# Altium schematic sheet Heat_Compress_V1.2.0.SchDoc
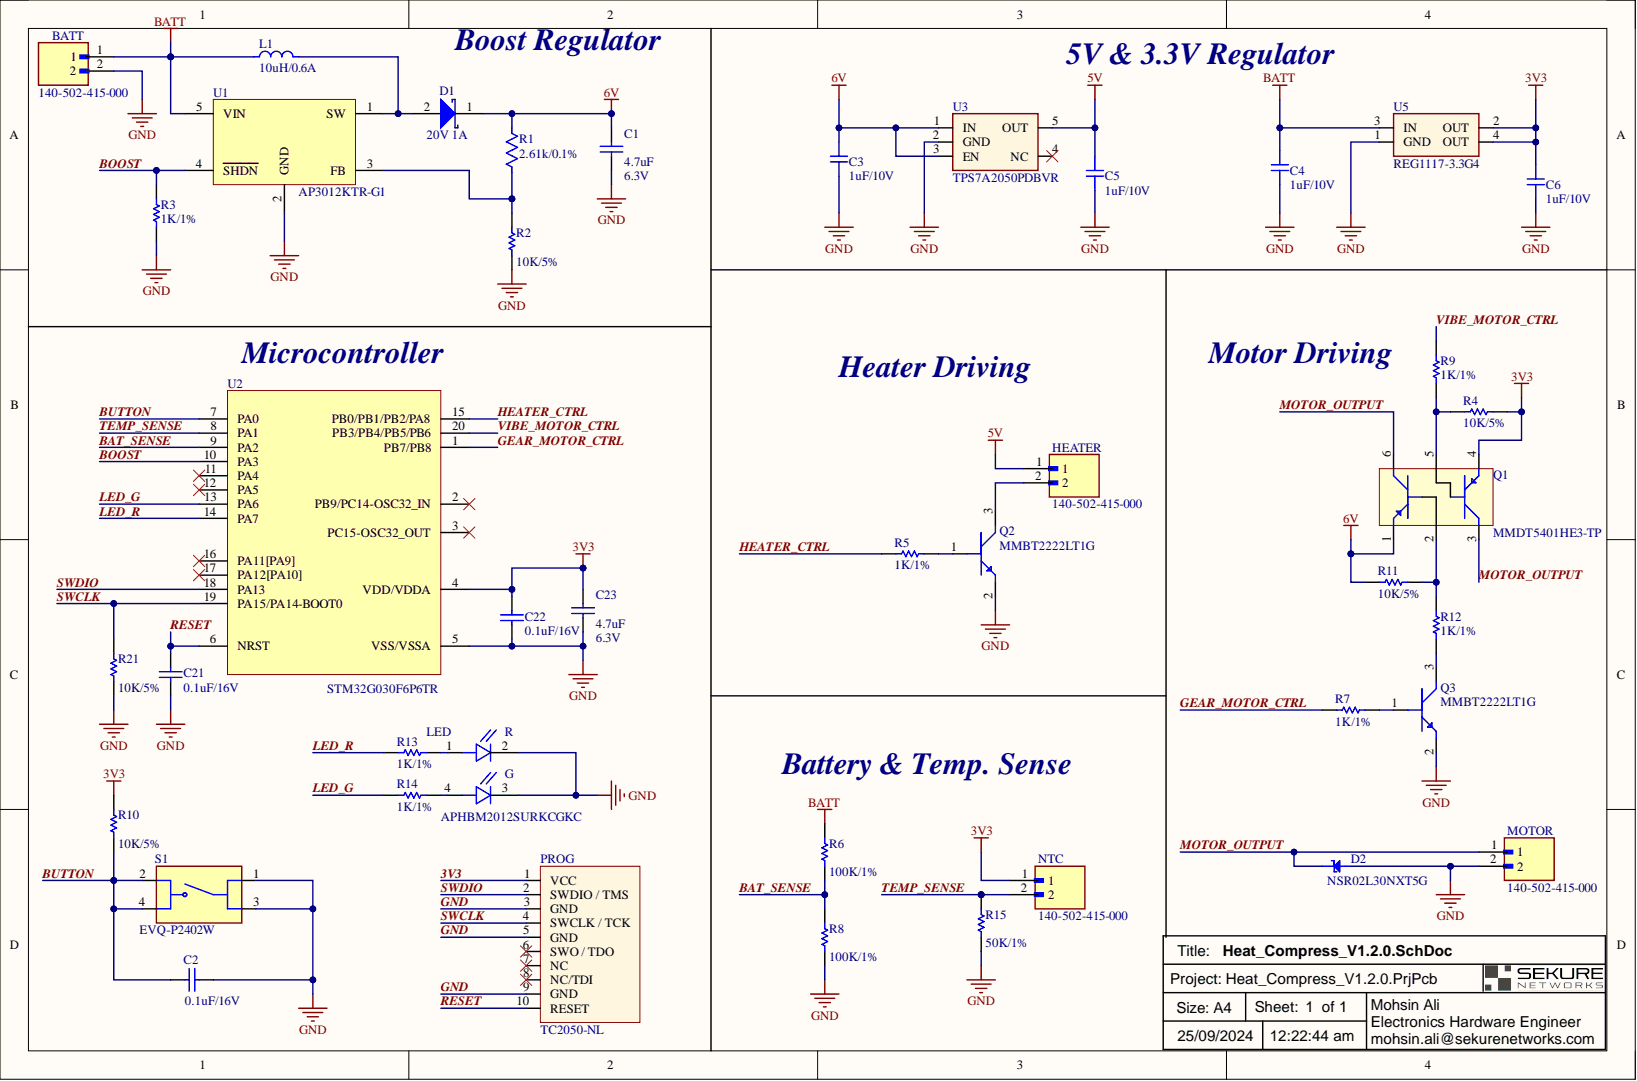
[diagram p0: Heat_Compress_V1.2.0.SchDoc]

## Parts
BATT  value 140-502-415-000  [140502415000]  (hidden comment: Connector)
C1  value 4.7uF  Capacitance: 4.7uF  Voltage Rating: 6.3V  [C0402]  (hidden comment: 4.7uF/6.3V)
C2  value 0.1uF/16V  [C0402]  (hidden comment: Ceramic Capacitor)
C3  value 1uF/10V  [CAP 0402]  (hidden comment: Ceramic Capacitor)
C4  value 1uF/10V  [CAP 0402]  (hidden comment: Ceramic Capacitor)
C5  value 1uF/10V  [CAP 0402]  (hidden comment: Ceramic Capacitor)
C6  value 1uF/10V  [CAP 0402]  (hidden comment: Ceramic Capacitor)
C21  value 0.1uF/16V  [C0402]  (hidden comment: Ceramic Capacitor)
C22  value 0.1uF/16V  [C0402]  (hidden comment: Ceramic Capacitor)
C23  value 4.7uF  Capacitance: 4.7uF  Voltage Rating: 6.3V  [C0402]  (hidden comment: 4.7uF/6.3V)
D1  value 20V 1A  Average Rectified Current: 1A  Max Repetitive Reverse Voltage (Vrrm): 20V  [SOD-123FL]  (hidden comment: Diode)
D2  value NSR02L30NXT5G  [2-DSN (0.60x0.30)]  (hidden comment: Schottky Diode)
HEATER  value 140-502-415-000  [140502415000]  (hidden comment: Connector)
L1  value 10uH/0.6A  [0.150" L x 0.150" W (3.80mm x 3.80mm)]  (hidden comment: Inductor)
LED  LED  value APHBM2012SURKCGKC  [LED_APHBM2012SURKCGKC]
MOTOR  value 140-502-415-000  [140502415000]  (hidden comment: Connector)
NTC  value 140-502-415-000  [140502415000]  (hidden comment: Connector)
PROG  value TC2050-NL  [TC2050]  (hidden comment: Programming Connector)
Q1  value MMDT5401HE3-TP  [SOT-363]  (hidden comment: Transistor)
Q2  value MMBT2222LT1G  [SOT23]  (hidden comment: Transistor)
Q3  value MMBT2222LT1G  [SOT23]  (hidden comment: Transistor)
R1  value 2.61k/0.1%  [RES 0402]  (hidden comment: Resistor)
R2  value 10K/5%  [R0402]  (hidden comment: Resistor)
R3  value 1K/1%  [R0402]  (hidden comment: Resistor)
R4  value 10K/5%  [R0402]  (hidden comment: Resistor)
R5  value 1K/1%  [R0402]  (hidden comment: Resistor)
R6  value 100K/1%  [R0402]  (hidden comment: Resistor)
R7  value 1K/1%  [R0402]  (hidden comment: Resistor)
R8  value 100K/1%  [R0402]  (hidden comment: Resistor)
R9  value 1K/1%  [R0402]  (hidden comment: Resistor)
R10  value 10K/5%  [R0402]  (hidden comment: Resistor)
R11  value 10K/5%  [R0402]  (hidden comment: Resistor)
R12  value 1K/1%  [R0402]  (hidden comment: Resistor)
R13  value 1K/1%  [R0402]  (hidden comment: Resistor)
R14  value 1K/1%  [R0402]  (hidden comment: Resistor)
R15  value 50K/1%  [R0402]  (hidden comment: Resistor)
R21  value 10K/5%  [R0402]  (hidden comment: Resistor)
S1  value EVQ-P2402W  [SW_EVQ-P2402W]  (hidden comment: Switch)
U1  AP3012KTR-G1  [SOT23-5]
U2  STM32G030F6P6TR  [TSOP65P640X120-20N]
U3  Regulator  value TPS7A2050PDBVR  [SOT23-5]
U5  Regulator  value REG1117-3.3G4  [SOT-223]

## Nets
5V: C5.2 HEATER.1 U3.5(OUT)
6V: C1.1 C3.2 D1.1 Q1.1 R1.1 R11.1 U3.1(IN) U3.3(EN)
BATT: BATT.1 C4.2 L1.1 R6.1 U1.5(VIN) U5.3(IN)
3V3: C6.2 C22.2 C23.1 NTC.1 PROG.1(VCC) Q1.4 R4.1 R10.2 U2.4(VDD/VDDA) U5.2(OUT) U5.4(OUT)
BAT_SENSE: R6.2 R8.1 U2.9(PA2)
BOOST: R3.1 U1.4(SHDN) U2.10(PA3)
BUTTON: C2.2 R10.1 S1.2 S1.4 U2.7(PA0)
GEAR_MOTOR_CTRL: R7.2 U2.1(PB7/PB8)
GND: BATT.2 C1.2 C2.1 C3.1 C4.1 C5.1 C6.1 C21.1 C22.1 C23.2 D2.2 LED.2 LED.3 MOTOR.2 PROG.3(GND) PROG.5(GND) PROG.9(GND) Q2.2 Q3.2 R2.1 R3.2 R8.2 R15.2 R21.1 S1.1 S1.3 U1.2(GND) U2.5(VSS/VSSA) U3.2(GND) U5.1(GND)
HEATER_CTRL: R5.2 U2.15(PB0/PB1/PB2/PA8)
LED_G: R14.2 U2.13(PA6)
LED_R: R13.2 U2.14(PA7)
MOTOR_OUTPUT: D2.1 MOTOR.1 Q1.3 Q1.6
RESET: C21.2 PROG.10(RESET) U2.6(NRST)
SWCLK: PROG.4(SWCLK_/_TCK) R21.2 U2.19(PA15/PA14-BOOT0)
SWDIO: PROG.2(SWDIO_/_TMS) U2.18(PA13)
TEMP_SENSE: NTC.2 R15.1 U2.8(PA1)
VIBE_MOTOR_CTRL: R9.1 U2.20(PB3/PB4/PB5/PB6)
NetD1_2: D1.2 L1.2 U1.1(SW)
NetHEATER_2: HEATER.2 Q2.3
NetLED_1: LED.1 R13.1
NetLED_4: LED.4 R14.1
NetQ1_2: Q1.2 R11.2 R12.1
NetQ1_5: Q1.5 R4.2 R9.2
NetQ2_1: Q2.1 R5.1
NetQ3_1: Q3.1 R7.1
NetQ3_3: Q3.3 R12.2
NetR1_2: R1.2 R2.2 U1.3(FB)

Not connected: PROG 6(SWO_/_TDO) 7(NC) 8(NC/TDI); U2 2(PB9/PC14-OSC32_IN) 3(PC15-OSC32_OUT) 11(PA4) 12(PA5) 16(PA11[PA9]) 17(PA12[PA10]); U3 4(NC)

## Library data of the parts
BATT HEATER MOTOR NTC = 140-502-415-000: EDAC - 140-502-415-000 - PIN HEADER CONN, BRASS, 2POS, 1ROW, 2MM   Source:  Datasheet; GateName_1: G$1; Component Kind: Standard; DeviceSetName: 140-502-415-000; DigiKey: 151-140-502-415-000-ND; Height: 5.25mm; Library Name: MainSchlib.SchLib; LibraryName: 140-502-415-000; Manufacturer: EDAC; Mouser_Part_Number: 587-140-502-415-000; Mouser_Price-Stock: https://www.mouser.co.uk/ProductDetail/EDAC/140-502-415-000?qs=zW32dvEIR3v5NSNdqekIBw%3D%3D; P/N: 140-502-415-000; Package: 140502415000; SymbolName_1: 140-502-415-000
C1 C23 = 885012206052: CAP CER 4.7UF 6.3V X5R 0402; Manufacturer: KEMET; P/N: C0402C475M9PAC7867; Tolerance: 10%; Case Code (Imperial): 0603; Case Code (Metric): 1608; Case/Package: 0402; Component Kind: Standard; ComponentLink1Description: https://datasheet.ciiva.com/176/mlccorderingcode-176788.pdf; ComponentLink1URL: https://datasheet.ciiva.com/176/mlccorderingcode-176788.pdf; ComponentLink2Description: https://datasheet.ciiva.com/176/mlccorderingcode-176789.pdf; ComponentLink2URL: https://datasheet.ciiva.com/176/mlccorderingcode-176789.pdf; ComponentLink3Description: https://datasheet.ciiva.com/176/mlccorderingcode-176790.pdf; ComponentLink3URL: https://datasheet.ciiva.com/176/mlccorderingcode-176790.pdf; ComponentLink4Description: https://datasheet.ciiva.com/5425/upy-gphc-x7r-6-3v-to-50v-8-12304-5425495.pdf; ComponentLink4URL: https://datasheet.ciiva.com/5425/upy-gphc-x7r-6-3v-to-50v-8-12304-5425495.pdf; ComponentLink5Description: https://datasheet.ciiva.com/16625/upy-gphc-x7r-6-3v-to-50v-8-16625527.pdf; ComponentLink5URL: https://datasheet.ciiva.com/16625/upy-gphc-x7r-6-3v-to-50v-8-16625527.pdf; ComponentLink6Description: https://datasheet.ciiva.com/8033/1734573-8033800.pdf; ComponentLink6URL: https://datasheet.ciiva.com/8033/1734573-8033800.pdf; ComponentLink7Description: https://datasheet.ciiva.com/8033/1734573-8033801.pdf; ComponentLink7URL: https://datasheet.ciiva.com/8033/1734573-8033801.pdf; ComponentLink8Description: https://datasheet.ciiva.com/28450/1489800-28450557.pdf; ComponentLink8URL: https://datasheet.ciiva.com/28450/1489800-28450557.pdf; ComponentLink9Description: https://datasheet.ciiva.com/7978/1489800-7978143.pdf; ComponentLink9URL: https://datasheet.ciiva.com/7978/1489800-7978143.pdf; ComponentLink10Description: https://datasheet.ciiva.com/25788/cl10b105kp8nnnc-25788304.pdf; ComponentLink10URL: https://datasheet.ciiva.com/25788/cl10b105kp8nnnc-25788304.pdf; ComponentLink11Description: https://datasheet.ciiva.com/16396/cl10b105kp8nnnc-16396970.pdf; ComponentLink11URL: https://datasheet.ciiva.com/16396/cl10b105kp8nnnc-16396970.pdf; ComponentLink12Description: https://datasheet.ciiva.com/26838/getdatasheetpartid-358410-26838426.pdf; ComponentLink12URL: https://datasheet.ciiva.com/26838/getdatasheetpartid-358410-26838426.pdf; ComponentLink13Description: http://www.samsungsem.com/kr/front/downloadcms.do?path=/kr/support/product-search/mlcc/__icsFiles/afieldfile/2014/11/05&fileName=C_CL10A475KQ8NNWC.pdf; ComponentLink13URL: http://www.samsungsem.com/kr/front/downloadcms.do?path=/kr/support/product-search/mlcc/__icsFiles/afieldfile/2014/11/05&fileName=C_CL10A475KQ8NNWC.pdf; DigiKey: 399-13068-1-ND; Length: 0.063inch; Library Name: Capacitor_Ceramic.IntLib; Max Operating Temperature: 85°C; Min Operating Temperature: -55°C; Mount: Surface Mount; Package Quantity: 1; Packaging: Tape and Reel; Pricing 1: 1=0.1, 10=0.075, 100=0.0333, 500=0.02376, 1000=0.01867 (USD); Signal Integrity: Cap; Simulation: Cap; Stock 1: 40000; Supplier 1: Digi-Key; Thickness: 0.035inch; Width: 0.031inch
C2 C21 C22 = Cap: CAP CER 0.1UF 16V X7R 0402; Manufacturer: Murata Electronics; P/N: GRM155R71C104KA88J; Category: Ceramic Capacitors; Component Kind: Standard; ComponentLink1Description: https://datasheet.ciiva.com/30062/tmk212bbj106kg-t-30062083.pdf?src-supplier=Onlinecomponents.com; ComponentLink1URL: https://datasheet.ciiva.com/30062/tmk212bbj106kg-t-30062083.pdf?src-supplier=Onlinecomponents.com; ComponentLink2Description: https://datasheet.ciiva.com/139/mlcc-all-e-139570.pdf?src-supplier=Digi-Key; ComponentLink2URL: https://datasheet.ciiva.com/139/mlcc-all-e-139570.pdf?src-supplier=Digi-Key; ComponentLink3Description: https://datasheet.ciiva.com/139/mlcc-all-e-139571.pdf?src-supplier=Digi-Key; ComponentLink3URL: https://datasheet.ciiva.com/139/mlcc-all-e-139571.pdf?src-supplier=Digi-Key; ComponentLink4Description: https://datasheet.ciiva.com/139/mlcc-all-e-139572.pdf?src-supplier=Digi-Key; ComponentLink4URL: https://datasheet.ciiva.com/139/mlcc-all-e-139572.pdf?src-supplier=Digi-Key; ComponentLink5Description: https://datasheet.ciiva.com/7975/1481447-7975820.pdf?src-supplier=Element14; ComponentLink5URL: https://datasheet.ciiva.com/7975/1481447-7975820.pdf?src-supplier=Element14; ComponentLink6Description: https://datasheet.ciiva.com/144/mlccorderingcode-144037.pdf?src-supplier=Digi-Key; ComponentLink6URL: https://datasheet.ciiva.com/144/mlccorderingcode-144037.pdf?src-supplier=Digi-Key; ComponentLink7Description: https://datasheet.ciiva.com/8033/1489800-8033669.pdf?src-supplier=Element14; ComponentLink7URL: https://datasheet.ciiva.com/8033/1489800-8033669.pdf?src-supplier=Element14; ComponentLink8Description: https://datasheet.ciiva.com/15189/getdatasheetpartid-321421-15189125.pdf?src-supplier=Verical; ComponentLink8URL: https://datasheet.ciiva.com/15189/getdatasheetpartid-321421-15189125.pdf?src-supplier=Verical; ComponentLink9Description: https://datasheet.ciiva.com/144/mlccorderingcode-144038.pdf?src-supplier=Digi-Key; ComponentLink9URL: https://datasheet.ciiva.com/144/mlccorderingcode-144038.pdf?src-supplier=Digi-Key; ComponentLink10Description: https://datasheet.ciiva.com/28450/1489800-28450342.pdf?src-supplier=Element14; ComponentLink10URL: https://datasheet.ciiva.com/28450/1489800-28450342.pdf?src-supplier=Element14; ComponentLink11Description: https://datasheet.ciiva.com/144/mlccorderingcode-144039.pdf?src-supplier=Digi-Key; ComponentLink11URL: https://datasheet.ciiva.com/144/mlccorderingcode-144039.pdf?src-supplier=Digi-Key; ComponentLink12Description: https://datasheet.ciiva.com/8033/1489800-8033671.pdf?src-supplier=Element14; ComponentLink12URL: https://datasheet.ciiva.com/8033/1489800-8033671.pdf?src-supplier=Element14; ComponentLink13Description: http://www.farnell.com/datasheets/1872206.pdf?src-supplier=Farnell; ComponentLink13URL: http://www.farnell.com/datasheets/1872206.pdf?src-supplier=Farnell; ComponentLink14Description: http://uk.rs-online.com/webdocs/144c/0900766b8144c113.pdf?src-supplier=RSComponents; ComponentLink14URL: http://uk.rs-online.com/webdocs/144c/0900766b8144c113.pdf?src-supplier=RSComponents; ComponentLink15Description: http://www.farnell.com/datasheets/2048008.pdf?src-supplier=Farnell; ComponentLink15URL: http://www.farnell.com/datasheets/2048008.pdf?src-supplier=Farnell; ComponentLink16Description: http://www.mouser.com/ds/2/281/c02e-2905.pdf?src-supplier=Mouser; ComponentLink16URL: http://www.mouser.com/ds/2/281/c02e-2905.pdf?src-supplier=Mouser; ComponentLink17Description: http://components.arrow.com/part/dataview/0323_06361249?src-supplier=Arrow+Europe; ComponentLink17URL: http://components.arrow.com/part/dataview/0323_06361249?src-supplier=Arrow+Europe; ComponentLink18Description: https://search.murata.co.jp/Ceramy/image/img/A01X/G101/ENG/GRM155R71E104KE14-01.pdf?src-supplier=Digi-Key; ComponentLink18URL: https://search.murata.co.jp/Ceramy/image/img/A01X/G101/ENG/GRM155R71E104KE14-01.pdf?src-supplier=Digi-Key; ComponentLink19Description: http://www.farnell.com/datasheets/2048008.pdf?src-supplier=Newark; ComponentLink19URL: http://www.farnell.com/datasheets/2048008.pdf?src-supplier=Newark; ComponentLink20Description: http://psearch.en.murata.com/capacitor/product/GRM155R71E104KE14%23.pdf?src-supplier=Avnet; ComponentLink20URL: http://psearch.en.murata.com/capacitor/product/GRM155R71E104KE14%23.pdf?src-supplier=Avnet; ComponentLink21Description: http://www.farnell.com/datasheets/2048008.pdf?src-supplier=Element14; ComponentLink21URL: http://www.farnell.com/datasheets/2048008.pdf?src-supplier=Element14; DigiKey: 490-6328-1-ND; Library Name: Miscellaneous Devices.IntLib; Pricing 1: 1=0.10000, 10=0.06400, 50=0.03500, 100=0.02860, 500=0.02040, 1000=0.01603, 2500=0.01458, 5000=0.01341 (USD); RoHS: Yes; RoHS Compliant: Yes; Signal Integrity: Cap; Simulation: CAP; Stock 1: 586694; Supplier 1: Digi-Key; Voltage Rating: 16V
C3-C6 = CL05A105MP5NNNC: CAP CER 1UF 10V X5R 0402; Manufacturer: Samsung; Tolerance: 20%; Capacitance: 1uF; Case Code (Imperial): 0402; Case Code (Metric): 1005; Case/Package: 0402; Category: Ceramic Capacitors; Component Kind: Standard; ComponentLink1Description: https://datasheet.ciiva.com/25802/cl05a105mp5nnnc-25802049.pdf?src-supplier=Digi-Key; ComponentLink1URL: https://datasheet.ciiva.com/25802/cl05a105mp5nnnc-25802049.pdf?src-supplier=Digi-Key; Construction: Flat; Depth: 0.5mm; Dielectric: X5R; DigiKey: 1276-1448-1-ND; Height: 0.5mm; Lead Free: Lead Free; Length: 1mm; Library Name: Ceramic Capacitors.IntLib; Max Operating Temperature: 85°C; Min Operating Temperature: -55°C; Mount: Surface Mount; P/N: CL05A105MP5NNNC; Package Quantity: 1; Packaging: Tape and Reel; Pricing 1: 1=0.10000 (USD); Radiation Hardening: No; RoHS: Yes; RoHS Compliant: Yes; Series: CL; Stock 1: 2439; Supplier 1: Digi-Key; Thickness: 0.022inch; Voltage Rating: 10V; Voltage Rating (DC): 10V; Width: 0.02inch
D1 = D Schottky: DIODE SCHOTTKY 20V 1A SOD123FL; Manufacturer: ON Semiconductor; Element Configuration: Single; Forward Voltage: 340mV; Max Forward Surge Current (Ifsm): 45A; Max Reverse Leakage Current: 600uA; P/N: MBR120VLSFT3G; Polarity: Standard; Component Kind: Standard; ComponentLink1Description: http://www.onsemi.com/pub_link/Collateral/MBR0520LT1-D.PDF; ComponentLink1URL: http://www.onsemi.com/pub_link/Collateral/MBR0520LT1-D.PDF; ComponentLink2Description: https://datasheet.ciiva.com/4715/mbr120vlsft1-d-107081-4715104.pdf; ComponentLink2URL: https://datasheet.ciiva.com/4715/mbr120vlsft1-d-107081-4715104.pdf; ComponentLink3Description: https://datasheet.ciiva.com/6380/mbr120vlsft1-d-6380907.pdf; ComponentLink3URL: https://datasheet.ciiva.com/6380/mbr120vlsft1-d-6380907.pdf; ComponentLink4Description: https://datasheet.ciiva.com/8294/mbr120vlsft1-d-8294603.pdf; ComponentLink4URL: https://datasheet.ciiva.com/8294/mbr120vlsft1-d-8294603.pdf; ComponentLink5Description: https://datasheet.ciiva.com/6380/mbr120vlsft1-d-6380910.pdf; ComponentLink5URL: https://datasheet.ciiva.com/6380/mbr120vlsft1-d-6380910.pdf; Current Rating: 1A; DigiKey: MBR120VLSFT3GOSCT-ND; Forward Current: 1A; Halogen Free: Halogen Free; Lead Free: Lead Free; Library Name: MainSchlib.SchLib; Max Operating Temperature: 125°C; Max Reverse Voltage (DC): 20V; Max Surge Current: 45A; Min Operating Temperature: -65°C; Number of Pins: 2; Output Current: 1A; Package Quantity: 10000; Packaging: Tape and Reel; Peak Non-Repetitive Surge Current: 45A; Peak Reverse Current: 600uA; Pricing 1: 1=0.42, 10=0.338, 100=0.2298, 500=0.17234, 1000=0.12926 (USD); Radiation Hardening: No; REACH SVHC: No SVHC; Signal Integrity: D Schottky; Simulation: SKYDIODE; Stock 1: 46165; Supplier 1: Digi-Key; Voltage Rating (DC): 20V
D2 = NSR02L30NXT5G: Rectifier Diode, Schottky, 1 Phase, 1 Element, 0.2A, 30V V(RRM), Silicon; Manufacturer: ON Semiconductor; Max Output current: 200mA; Max Power Dissipation: 735mW; Number of Elements: 1; Qualification Status: Not Qualified; Category: Schottky Diodes; Component Kind: Standard; ComponentLink1Description: https://datasheet.ciiva.com:443/pdfs/VipMasterIC/IC/ONSM/ONSM-S-A0003065631/ONSM-S-A0003065631-1.pdf?src-supplier=IHS+Markit; ComponentLink1URL: https://datasheet.ciiva.com:443/pdfs/VipMasterIC/IC/ONSM/ONSM-S-A0003065631/ONSM-S-A0003065631-1.pdf?src-supplier=IHS+Markit; ComponentLink2Description: https://datasheet.ciiva.com/4714/nsr02l30-d-113448-4714531.pdf?src-supplier=Mouser; ComponentLink2URL: https://datasheet.ciiva.com/4714/nsr02l30-d-113448-4714531.pdf?src-supplier=Mouser; ComponentLink3Description: https://datasheet.ciiva.com/8293/nsr02l30-d-8293859.pdf?src-supplier=Avnet; ComponentLink3URL: https://datasheet.ciiva.com/8293/nsr02l30-d-8293859.pdf?src-supplier=Avnet; ComponentLink4Description: https://datasheet.ciiva.com/923/nsr02l30-d-923110.pdf?src-supplier=Digi-Key; ComponentLink4URL: https://datasheet.ciiva.com/923/nsr02l30-d-923110.pdf?src-supplier=Digi-Key; DigiKey: NSR02L30NXT5GOSCT-ND; Diode Element Material: SILICON; Diode Type: RECTIFIER; Library Name: Diode.IntLib; Max Operating Temperature: 150°C; P/N: NSR02L30NXT5G; Package Shape: Rectangular; Package Style: CHIP CARRIERMeter; Pins: 2; Pricing 1: 1=0.51000, 10=0.38100, 100=0.23770, 500=0.16260, 1000=0.12508, 2000=0.11257 (USD); Reach SVHC Compliant: Yes; Rep Pk Reverse Voltage-Max: 30V; RoHS: Yes; RoHS Compliant: Yes; Stock 1: 35065; Supplier 1: Digi-Key; Surface Mount: Yes; Technology: SCHOTTKY; Terminal Form: No Lead; Terminal Position: BOTTOM
L1 = CDRH3D14/HPNP-100NC: General Purpose Inductor, 10uH, 25%, 1 Element, Ferrite-Core, SMD, 1616; Manufacturer: Sumida; Case/Size Code: 1616; Channels: 1; DC Resistance (DCR): 440mR; Inductor Application: POWER INDUCTOR; Max Current Rating: 670mA; Package Height: 1.35mm; Package Length: 3.8mm; Shape/Size Description: RECTANGULAR PACKAGE; Shielding: Shielded; Test Frequency: 100kHz; Category: Fixed Inductors; Component Kind: Standard; ComponentLink1Description: https://datasheet.ciiva.com:443/pdfs/VipMasterIC/IC/SMDC/SMDC-S-A0002853812/SMDC-S-A0002853812-1.pdf?src-supplier=IHS+Markit; ComponentLink1URL: https://datasheet.ciiva.com:443/pdfs/VipMasterIC/IC/SMDC/SMDC-S-A0002853812/SMDC-S-A0002853812-1.pdf?src-supplier=IHS+Markit; ComponentLink2Description: http://www.sumida.com/products/pdf/CDRH3D14HP.pdf?src-supplier=Avnet; ComponentLink2URL: http://www.sumida.com/products/pdf/CDRH3D14HP.pdf?src-supplier=Avnet; ComponentLink3Description: http://products.sumida.com/products/pdf/CDRH3D14HP.pdf?src-supplier=Digikey; ComponentLink3URL: http://products.sumida.com/products/pdf/CDRH3D14HP.pdf?src-supplier=Digikey; ComponentLink4Description: https://www.sumida.com/products/pdf/CDRH3D14HP.pdf?src-supplier=Mouser; ComponentLink4URL: https://www.sumida.com/products/pdf/CDRH3D14HP.pdf?src-supplier=Mouser; ComponentLink5Description: http://www.sumida.com/products/pdf/CDRH3D14,3D14HP,3D14LD.pdf?src-supplier=Future+Electronics; ComponentLink5URL: http://www.sumida.com/products/pdf/CDRH3D14,3D14HP,3D14LD.pdf?src-supplier=Future+Electronics; ComponentLink6Description: https://datasheet.octopart.com/CDRH3D14-HPNP-100NC-Sumida-datasheet-73726305.pdf?src-supplier=Future+Electronics; ComponentLink6URL: https://datasheet.octopart.com/CDRH3D14-HPNP-100NC-Sumida-datasheet-73726305.pdf?src-supplier=Future+Electronics; Construction: Magnetically Shielded; Core Material: Ferrite; DigiKey: 308-1969-1-ND; Inductance-Nom (L): 10uH; Inductor Type: GENERAL PURPOSE INDUCTOR; Library Name: Inductors_NEW.IntLib; Max Operating Temperature: 105°C; Min Operating Temperature: -40°C; P/N: CDRH3D14/HPNP-100NC; Package Style: SMTMeter; Package Width: 3.8mm; Packaging: Tape and Reel; Pins: 2; Pricing: 1=0.7, 10=0.603, 25=0.5524, 50=0.5022, 100=0.447, 250=0.42188, 500=0.36162 (USD); RoHS: Yes; RoHS Compliant: Yes; Series: CDRH3D14/HP; Stock: 1000; Supplier: Digikey; Surface Mount: Yes; Terminal Placement: Dual Ended; Termination: Wraparound; Tolerance: 25%
LED = APHBM2012SURKCGKC: Green, Red 570nm Green, 630nm Red LED Indication - Discrete 2.1V Green, 1.95V Red 0805 _2012 Metric_; Manufacturer: Kingbright; Component Kind: Standard; DigiKey: 754-1093-2-ND; Library Name: MainSchlib.SchLib; P/N: APHBM2012SURKCGKC; Package: 0805 Kingbright; SnapEDA_Link: https://www.snapeda.com/parts/APHBM2012SURKCGKC/Kingbright/view-part/522213/?ref=snap
PROG = TC2050: “No Legs” 10-pin Plug-of-Nails™ Cable fitted with a 10-pin 0.1″ pitch ribbon connector.; Assembly: DNP; Component Kind: Standard; Library Name: Programming Connectors.IntLib
Q1 = MMDT5401HE3-TP: Trans GP BJT PNP 150V 0.6A 200mW 6-Pin SOT-363 T/R Automotive AEC-Q101; Manufacturer: MCC; Component Kind: Standard; ComponentLink1Description: https://datasheet.octopart.com/MMDT5401HE3-TP-Micro-Commercial-datasheet-176339710.pdf?src-supplier=Future+Electronics; ComponentLink1URL: https://datasheet.octopart.com/MMDT5401HE3-TP-Micro-Commercial-datasheet-176339710.pdf?src-supplier=Future+Electronics; DigiKey: 353-MMDT5401HE3-TPCT-ND; Library Name: Transistor.IntLib; P/N: MMDT5401HE3-TP; Pricing: 1=0.37, 10=0.261, 100=0.1318, 500=0.1168, 1000=0.0909 (USD); Stock: 5840; Supplier: Digikey
Q2 Q3 = MMBT2222LT1G: Small Signal Bipolar Transistor, 0.6A I(C), 30V V(BR)CEO, 1-Element, NPN, Silicon, TO-236; Manufacturer: ON Semiconductor; DC Current Gain-Min (hFE): 75; Frequency: 250MHz; Max Collector Current: 600mA; Number of Elements: 1; Package Body Material: Plastic; Power Dissipation-Max (Abs): 225mW; Qualification Status: Not Qualified; Time @ Peak Reflow Temperature-Max (s): 40s; Transistor Application: SWITCHING; Turn-off Time-Max (toff): 285ns; Turn-on Time-Max (ton): 35ns; Category: BJTs; Collector-emitter Voltage-Max: 30V; Component Kind: Standard; ComponentLink1Description: https://datasheet.ciiva.com:443/pdfs/VipMasterIC/IC/ONSM/ONSM-S-A0002809824/ONSM-S-A0002809824-1.pdf?src-supplier=IHS+Markit; ComponentLink1URL: https://datasheet.ciiva.com:443/pdfs/VipMasterIC/IC/ONSM/ONSM-S-A0002809824/ONSM-S-A0002809824-1.pdf?src-supplier=IHS+Markit; ComponentLink2Description: https://datasheet.ciiva.com/976/mmbt2222lt1-d-976368.pdf?src-supplier=Digikey; ComponentLink2URL: https://datasheet.ciiva.com/976/mmbt2222lt1-d-976368.pdf?src-supplier=Digikey; ComponentLink3Description: https://datasheet.ciiva.com/976/mmbt2222lt1-d-976369.pdf?src-supplier=Digikey; ComponentLink3URL: https://datasheet.ciiva.com/976/mmbt2222lt1-d-976369.pdf?src-supplier=Digikey; ComponentLink4Description: https://datasheet.ciiva.com/976/mmbt2222lt1-d-976370.pdf?src-supplier=Digikey; ComponentLink4URL: https://datasheet.ciiva.com/976/mmbt2222lt1-d-976370.pdf?src-supplier=Digikey; ComponentLink5Description: https://datasheet.ciiva.com/4721/mmbt2222lt1-d-80103-4721468.pdf?src-supplier=Mouser; ComponentLink5URL: https://datasheet.ciiva.com/4721/mmbt2222lt1-d-80103-4721468.pdf?src-supplier=Mouser; ComponentLink6Description: https://datasheet.ciiva.com/11198/mmbt2222lt1-d-11198974.pdf?src-supplier=Avnet; ComponentLink6URL: https://datasheet.ciiva.com/11198/mmbt2222lt1-d-11198974.pdf?src-supplier=Avnet; ComponentLink7Description: https://datasheet.ciiva.com/11201/mmbt2222lt1-d-11201758.pdf?src-supplier=Avnet; ComponentLink7URL: https://datasheet.ciiva.com/11201/mmbt2222lt1-d-11201758.pdf?src-supplier=Avnet; ComponentLink8Description: https://datasheet.ciiva.com/15224/getdatasheetpartid-91924-15224358.pdf?src-supplier=Verical; ComponentLink8URL: https://datasheet.ciiva.com/15224/getdatasheetpartid-91924-15224358.pdf?src-supplier=Verical; DigiKey: MMBT2222LT1GOSCT-ND; JEDEC-95 Code: TO-236; JESD-30 Code: R-PDSO-G3; JESD-609 Code: e3; Library Name: Transistor.IntLib; Max Operating Temperature: 150°C; Moisture Sensitivity Level: 1; P/N: MMBT2222LT1G; Package Shape: Rectangular; Package Style: SMALL OUTLINEMeter; Peak Reflow Temperature (Cel): 260°C; Pins: 3; Polarity/Channel Type: NPN; Pricing: 1=0.14, 10=0.085, 100=0.0523, 500=0.03782, 1000=0.03311 (USD); Reach SVHC Compliant: Yes; RoHS: Yes; RoHS Compliant: Yes; Stock: 84926; Supplier: Digikey; Surface Mount: Yes; Terminal Finish: Tin; Terminal Form: Gull Wing; Terminal Position: DUAL; Transistor Element Material: SILICON
R1 = RT0402BRD072K61L: Fixed Resistor, Thin Film, 0.0625W, 2610ohm, 50V, 0.1% +/-Tol, 25ppm/Cel, Surface Mount, 0402; Manufacturer: Yageo; Additional Feature: HIGH PRECISION; Package Height: 0.3mm; Package Length: 1mm; Package Width: 0.5mm; Power: 62.5mW; Rated Temperature: 70°C; Temperature Coefficient: 25ppm/°C; Case/Package: 0402; Category: Chip SMD Resistors; Component Kind: Standard; ComponentLink1Description: https://datasheet.ciiva.com:443/pdfs/VipMasterIC/IC/YAGO/YAGO-S-A0008510989/YAGO-S-A0008510989-1.pdf?src-supplier=IHS+Markit; ComponentLink1URL: https://datasheet.ciiva.com:443/pdfs/VipMasterIC/IC/YAGO/YAGO-S-A0008510989/YAGO-S-A0008510989-1.pdf?src-supplier=IHS+Markit; ComponentLink2Description: https://datasheet.ciiva.com/2582/pyu-rt-1-to-0-05-rohs-l-4-2582292.pdf?src-supplier=Digikey; ComponentLink2URL: https://datasheet.ciiva.com/2582/pyu-rt-1-to-0-05-rohs-l-4-2582292.pdf?src-supplier=Digikey; Construction: Chip; DigiKey: YAG1403CT-ND; Library Name: Resistors.IntLib; Max Operating Temperature: 155°C; Min Operating Temperature: -55°C; Mounting Technology: Surface Mount; P/N: RT0402BRD072K61L; Package Style: SMTMeter; Packaging: Tape and Reel; Pins: 2; Pricing: 1=0.16, 10=0.121, 50=0.0958, 100=0.0859, 500=0.0654, 1000=0.0578, 5000=0.04283 (USD); Reach SVHC Compliant: Yes; Resistor Type: FIXED RESISTOR; RoHS: Yes; RoHS Compliant: Yes; Stock: 18420; Supplier: Digikey; Technology: THIN FILM; Termination: Wraparound; Tolerance: 0.1%; Working Voltage: 50V
R2 R4 R10 R11 R21 = Res1: RES 10K OHM 5% 1/16W 0402; Manufacturer: Yageo; Max Power Dissipation: 100mW; P/N: RC0402JR-0710KL; Resistance: 10kR; Temperature Coefficient: 150ppm/°C; Case Code (Imperial): 0603; Case Code (Metric): 1608; Case/Package: 0402; Category: Chip SMD Resistors; Component Kind: Standard; ComponentLink1Description: https://datasheet.ciiva.com/11808/0900766b80c66297-11808764.pdf?src-supplier=RSComponents; ComponentLink1URL: https://datasheet.ciiva.com/11808/0900766b80c66297-11808764.pdf?src-supplier=RSComponents; ComponentLink2Description: https://datasheet.ciiva.com/46394/1018594-46394954.pdf?src-supplier=Element14; ComponentLink2URL: https://datasheet.ciiva.com/46394/1018594-46394954.pdf?src-supplier=Element14; ComponentLink3Description: https://datasheet.ciiva.com/7849/1018594-7849818.pdf?src-supplier=Element14; ComponentLink3URL: https://datasheet.ciiva.com/7849/1018594-7849818.pdf?src-supplier=Element14; ComponentLink4Description: https://datasheet.ciiva.com/7849/1018594-7849819.pdf?src-supplier=Element14; ComponentLink4URL: https://datasheet.ciiva.com/7849/1018594-7849819.pdf?src-supplier=Element14; ComponentLink5Description: https://datasheet.ciiva.com/15189/getdatasheetpartid-323697-15189339.pdf?src-supplier=Verical; ComponentLink5URL: https://datasheet.ciiva.com/15189/getdatasheetpartid-323697-15189339.pdf?src-supplier=Verical; ComponentLink6Description: https://datasheet.ciiva.com/7660/38568-7660787.pdf?src-supplier=Element14; ComponentLink6URL: https://datasheet.ciiva.com/7660/38568-7660787.pdf?src-supplier=Element14; ComponentLink7Description: https://datasheet.ciiva.com/7849/1784430-7849813.pdf?src-supplier=Element14; ComponentLink7URL: https://datasheet.ciiva.com/7849/1784430-7849813.pdf?src-supplier=Element14; ComponentLink8Description: https://datasheet.ciiva.com/7849/1686128-7849814.pdf?src-supplier=Element14; ComponentLink8URL: https://datasheet.ciiva.com/7849/1686128-7849814.pdf?src-supplier=Element14; ComponentLink9Description: https://datasheet.ciiva.com/7849/1784430-7849815.pdf?src-supplier=Element14; ComponentLink9URL: https://datasheet.ciiva.com/7849/1784430-7849815.pdf?src-supplier=Element14; ComponentLink10Description: https://datasheet.ciiva.com/22747/1018594-22747512.pdf?src-supplier=Element14; ComponentLink10URL: https://datasheet.ciiva.com/22747/1018594-22747512.pdf?src-supplier=Element14; ComponentLink11Description: https://datasheet.ciiva.com/12667/pyu-rc0402-51-rohs-l-6-r-12667067.pdf?src-supplier=Mouser; ComponentLink11URL: https://datasheet.ciiva.com/12667/pyu-rc0402-51-rohs-l-6-r-12667067.pdf?src-supplier=Mouser; ComponentLink12Description: https://datasheet.ciiva.com/7983/1018594-7983486.pdf?src-supplier=Element14; ComponentLink12URL: https://datasheet.ciiva.com/7983/1018594-7983486.pdf?src-supplier=Element14; ComponentLink13Description: http://www.yageo.com/NewPortal/yageodocoutput?fileName=/pdf/R-Chip/PYu-RC_51_RoHS_P_0.pdf&src-supplier=Digi-Key; ComponentLink13URL: http://www.yageo.com/NewPortal/yageodocoutput?fileName=/pdf/R-Chip/PYu-RC_51_RoHS_P_0.pdf&src-supplier=Digi-Key; DigiKey: 311-10KJRCT-ND; Features: Moisture Resistant; Height: 0.022inch; Length: 0.063inch; Library Name: Miscellaneous Devices.IntLib; Max Operating Temperature: 155°C; Min Operating Temperature: -55°C; Number of Terminations: 2; Packaging: Tape and Reel; Pricing 1: 1=0.10000, 10=0.04200, 50=0.04200, 100=0.01700, 1000=0.00800, 2500=0.00700, 5000=0.00500, 10000=0.00500 (USD); Resistor Type: Thick Film; RoHS: Yes; RoHS Compliant: Yes; Signal Integrity: Res; Simulation: RESISTOR; Stock 1: 45933; Supplier 1: Digi-Key; Tolerance: 5%; Width: 0.031inch
R3 R5 R7 R9 R12-R14 = Res1: RES 1K OHM 1% 1/16W 0402; Manufacturer: Yageo; Max Power Dissipation: 100mW; P/N: RC0402FR-071KL; Resistance: 10kR; Temperature Coefficient: 150ppm/°C; Tolerance: 5%; Case Code (Imperial): 0603; Case Code (Metric): 1608; Case/Package: 0603; Category: Chip SMD Resistors; Component Kind: Standard; DigiKey: 311-1.00KLRCT-ND; Features: Moisture Resistant; Height: 0.022inch; Length: 0.063inch; Library Name: Miscellaneous Devices.IntLib; Max Operating Temperature: 155°C; Min Operating Temperature: -55°C; Number of Terminations: 2; Packaging: Tape and Reel; Pricing 1: 1=0.10000, 10=0.04200, 50=0.04200, 100=0.01700, 1000=0.00800, 2500=0.00700, 5000=0.00500, 10000=0.00500 (USD); Resistor Type: Thick Film; RoHS: Yes; RoHS Compliant: Yes; Signal Integrity: Res; Simulation: RESISTOR; Stock 1: 45933; Supplier 1: Digi-Key; Width: 0.031inch
R6 R8 = Res1: RES 100K OHM 1% 1/16W 0402; Manufacturer: Yageo; Max Power Dissipation: 100mW; P/N: RC0402FR-07100KL; Resistance: 10kR; Temperature Coefficient: 150ppm/°C; Tolerance: 5%; Case Code (Imperial): 0603; Case Code (Metric): 1608; Case/Package: 0603; Category: Chip SMD Resistors; Component Kind: Standard; DigiKey: 311-100KLRCT-ND; Features: Moisture Resistant; Height: 0.022inch; Length: 0.063inch; Library Name: Miscellaneous Devices.IntLib; Max Operating Temperature: 155°C; Min Operating Temperature: -55°C; Number of Terminations: 2; Packaging: Tape and Reel; Pricing 1: 1=0.10000, 10=0.04200, 50=0.04200, 100=0.01700, 1000=0.00800, 2500=0.00700, 5000=0.00500, 10000=0.00500 (USD); Resistor Type: Thick Film; RoHS: Yes; RoHS Compliant: Yes; Signal Integrity: Res; Simulation: RESISTOR; Stock 1: 45933; Supplier 1: Digi-Key; Width: 0.031inch
R15 = Res1: D10/CRCW0402 100 50K 1% ET7 E3; Manufacturer: Vishay Dale; Max Power Dissipation: 100mW; P/N: CRCW040250K0FKED; Resistance: 10kR; Temperature Coefficient: 150ppm/°C; Tolerance: 5%; Case Code (Imperial): 0603; Case Code (Metric): 1608; Case/Package: 0603; Category: Chip SMD Resistors; Component Kind: Standard; DigiKey: 541-CRCW040250K0FKEDCT-ND; Features: Moisture Resistant; Height: 0.022inch; Length: 0.063inch; Library Name: Miscellaneous Devices.IntLib; Max Operating Temperature: 155°C; Min Operating Temperature: -55°C; Number of Terminations: 2; Packaging: Tape and Reel; Pricing 1: 1=0.10000, 10=0.04200, 50=0.04200, 100=0.01700, 1000=0.00800, 2500=0.00700, 5000=0.00500, 10000=0.00500 (USD); Resistor Type: Thick Film; RoHS: Yes; RoHS Compliant: Yes; Signal Integrity: Res; Simulation: RESISTOR; Stock 1: 45933; Supplier 1: Digikey; Width: 0.031inch
S1 = EVQ-P2402W: SWITCH TACTILE SPST-NO 0.02A 15V; Manufacturer: Panasonic Electronic Components; Availability: Unavailable; Component Kind: Standard; DigiKey: P11081SCT-ND; Library Name: MainSchlib.SchLib; P/N: EVQ-P2402W; Package: None; Price: None; Supplier 1: Digi-Key
U1 = AP3012KTR-G1: IC REG BOOST ADJ 0.5A SOT25; Manufacturer: Diodes; Efficiency: 85%; Max Input Voltage: 16V; Max Output Current: 500mA; Max Output Voltage: 29V; Min Input Voltage: 2.6V; Min Output Voltage: 1.25V; Min Supply Voltage: 1V; Number of Outputs: 1; Operating Supply Current: 100nA; Output Configuration: Positive; Output Type: Adjustable; Switching Frequency: 1.5MHz; Synchronous Rectifier: No; Case/Package: SOT-23-5; Category: Power Supply Modules; Component Kind: Standard; ComponentLink1Description: https://datasheet.ciiva.com/29885/ap3012-29885792.pdf?src-supplier=Avnet; ComponentLink1URL: https://datasheet.ciiva.com/29885/ap3012-29885792.pdf?src-supplier=Avnet; ComponentLink2Description: https://datasheet.ciiva.com/29735/ap3012-29735077.pdf?src-supplier=Digi-Key; ComponentLink2URL: https://datasheet.ciiva.com/29735/ap3012-29735077.pdf?src-supplier=Digi-Key; ComponentLink3Description: https://datasheet.ciiva.com/29735/ap3012-29735068.pdf?src-supplier=Digi-Key; ComponentLink3URL: https://datasheet.ciiva.com/29735/ap3012-29735068.pdf?src-supplier=Digi-Key; DigiKey: AP3012KTR-G1DICT-ND; Lead Free: Lead Free; Library Name: MainSchlib.SchLib; Max Operating Temperature: 85°C; Min Operating Temperature: -40°C; Mount: Surface Mount; Number of Pins: 5; Operating Supply Voltage: 16V; Output Current: 500mA; Output Voltage: 29V; P/N: AP3012KTR-G1; Package Quantity: 3000; Packaging: Tape and Reel; Pricing 1: 1=0.47000, 10=0.38000, 25=0.34760, 100=0.23430, 250=0.19392, 500=0.17776, 1000=0.13332 (USD); RoHS: Yes; Stock 1: 74092; Supplier 1: Digi-Key; Topology: Boost; Value: AP3012KTR-G1; Weight: 0.001058oz
U2 = STM32G030F6P6TR: IC MCU 32BIT 32KB FLASH 20TSSOP; Manufacturer: STMicroelectronics; Category: Microcontrollers; Component Kind: Standard; ComponentLink1Description: https://www.st.com/resource/en/datasheet/stm32g030f6.pdf?src-supplier=Digi-Key; ComponentLink1URL: https://www.st.com/resource/en/datasheet/stm32g030f6.pdf?src-supplier=Digi-Key; DigiKey: 497-STM32G030F6P6TRCT-ND; Library Name: Symbols.SchLib; P/N: STM32G030F6P6TR; Pricing 1: 1=1.75000, 10=1.57200, 25=1.48320, 100=1.26380, 250=1.18668, 500=1.03834, 1000=0.86034 (USD); RoHS: Yes; RoHS Compliant: Yes; Stock 1: 13536; Supplier 1: Digi-Key; Value: STM32G030F6P6TR
U3 = TPS7A2050PDBVR: Fixed Positive LDO Regulator, 5V, 0.14V Dropout, PDSO5; Manufacturer: Texas Instruments; Category: Voltage Regulators - Linear; Component Kind: Standard; DigiKey: 296-TPS7A2050PDBVRCT-ND; Library Name: Regulators.IntLib; P/N: TPS7A2050PDBVR; Pricing: 1=0.33, 10=0.28, 25=0.2608, 100=0.2087, 250=0.19384, 500=0.164, 1000=0.13125 (USD); RoHS: Yes; Stock: 174940; Supplier: Digikey
U5 = REG1117-3.3G4: 800-mA, 15-V, linear voltage regulator 4-SOT-223 -40 to 125; Manufacturer: Texas Instruments; Accuracy: 1%; Dropout Voltage: 1.1V; Input Bias Current: 4mA; Max Input Voltage: 15V; Max Output Current: 800mA; Max Output Voltage: 3.3V; Min Input Voltage: 3.8V; Number of Outputs: 1; Output Type: Fixed; Polarity: Positive; Case/Package: SOT-223; Category: Voltage Regulators - Linear; Component Kind: Standard; ComponentLink1Description: https://datasheet.ciiva.com/2064/reg1117-2064563.pdf?src-supplier=Digi-Key; ComponentLink1URL: https://datasheet.ciiva.com/2064/reg1117-2064563.pdf?src-supplier=Digi-Key; DigiKey: 296-52924-ND; Lead Free: Lead Free; Library Name: Regulators.IntLib; Max Operating Temperature: 125°C; Min Current Limit: 800mA; Min Operating Temperature: 0°C; Min Output Voltage: 3.3V; Nominal Output Voltage: 3.3V; Number of Pins: 4; Number of Regulators: 1; Output Current: 800mA; Output Voltage: 3.3V; Output Voltage Accuracy: 1%; P/N: REG1117-3.3G4; Package Quantity: 80; Packaging: Rail/Tube; Pricing 1: 1=3.08000, 10=2.76700, 25=2.61040, 100=2.22390, 250=2.08820, 500=1.82718, 1000=1.72375 (USD); Quiescent Current: 4mA; Radiation Hardening: No; REACH SVHC: No SVHC; RoHS: Yes; RoHS Compliant: Yes; Stock 1: 3710; Supplier 1: Digi-Key
